# Altium schematic sheet P10_HDMI Framer.SchDoc
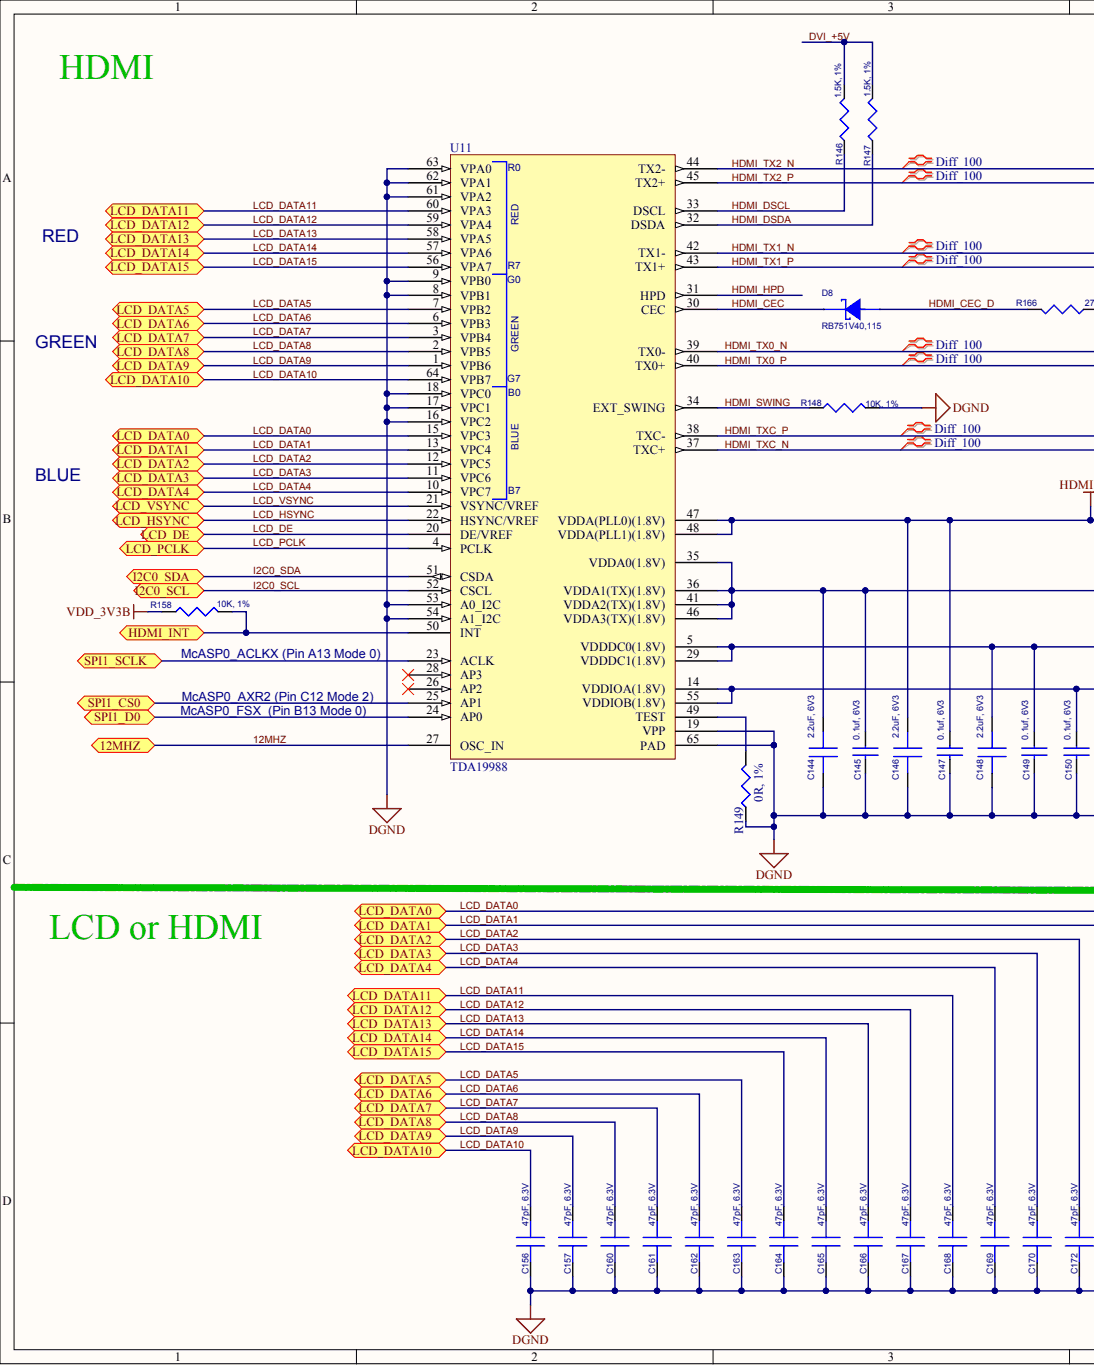
[diagram p0: P10_HDMI Framer.SchDoc, left]
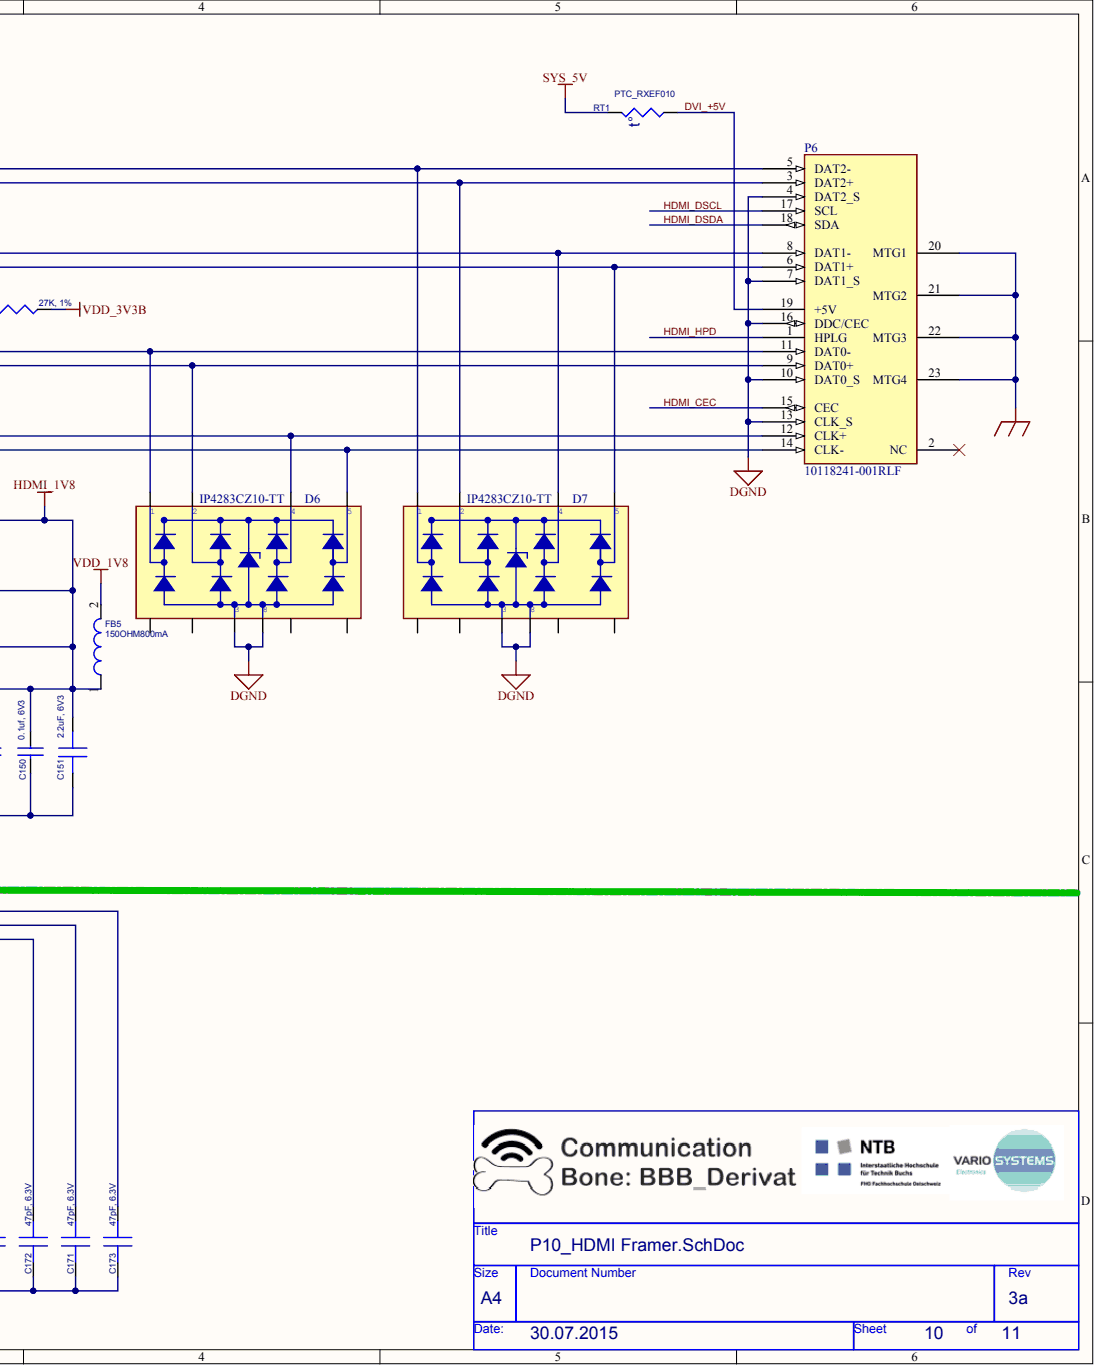
[diagram p1: P10_HDMI Framer.SchDoc, right]

## Parts
C144  2.2uF, 6V3  [402]
C145  0.1uf, 6V3  [402]
C146  2.2uF, 6V3  [402]
C147  0.1uf, 6V3  [402]
C148  2.2uF, 6V3  [402]
C149  0.1uf, 6V3  [402]
C150  0.1uf, 6V3  [402]
C151  2.2uF, 6V3  [402]
C156  47pF, 6.3V  [402]
C157  47pF, 6.3V  [402]
C160  47pF, 6.3V  [402]
C161  47pF, 6.3V  [402]
C162  47pF, 6.3V  [402]
C163  47pF, 6.3V  [402]
C164  47pF, 6.3V  [402]
C165  47pF, 6.3V  [402]
C166  47pF, 6.3V  [402]
C167  47pF, 6.3V  [402]
C168  47pF, 6.3V  [402]
C169  47pF, 6.3V  [402]
C170  47pF, 6.3V  [402]
C171  47pF, 6.3V  [402]
C172  47pF, 6.3V  [402]
C173  47pF, 6.3V  [402]
D6  IP4283CZ10-TT  [TSSOP10]
D7  IP4283CZ10-TT  [TSSOP10]
D8  RB751V40,115  [SOD323]
FB5  150OHM800mA  [SM-7351-IND-0805]
P6  10118241-001RLF  [CONN19_HDMI]
R146  1.5K, 1%  [402]
R147  1.5K, 1%  [402]
R148  10K, 1%  [402]
R149  0R, 1%  [402]
R158  10K, 1%  [402]
R166  27K, 1%  [402]
RT1  PTC_RXEF010  [POLYSW200-5638-310]
U11  TDA19988  [QFN64S9X9P5]

## Ports
12MHZ, HDMI_INT, I2C0_SCL, I2C0_SDA, LCD_DATA0, LCD_DATA1, LCD_DATA2, LCD_DATA3, LCD_DATA4, LCD_DATA5, LCD_DATA6, LCD_DATA7, LCD_DATA8, LCD_DATA9, LCD_DATA10, LCD_DATA11, LCD_DATA12, LCD_DATA13, LCD_DATA14, LCD_DATA15, LCD_DE, LCD_HSYNC, LCD_PCLK, LCD_VSYNC, SPI1_CS0, SPI1_D0, SPI1_SCLK

## Nets
DGND: C144.2 C145.2 C146.2 C147.2 C148.2 C149.2 C150.2 C151.2 C156.2 C157.2 C160.2 C161.2 C162.2 C163.2 C164.2 C165.2 C166.2 C167.2 C168.2 C169.2 C170.2 C171.2 C172.2 C173.2 D6.3 D6.8 D7.3 D7.8 P6.4(DAT2_S) P6.7(DAT1_S) P6.10(DAT0_S) P6.13(CLK_S) P6.16(DDC/CEC) R148.2 R149.2 U11.8(VPB1) U11.9(VPB0) U11.16(VPC2) U11.17(VPC1) U11.18(VPC0) U11.19(VPP) U11.53(A0_I2C) U11.54(A1_I2C) U11.61(VPA2) U11.62(VPA1) U11.63(VPA0) U11.65(PAD)
GND_EARTH: P6.20(MTG1) P6.21(MTG2) P6.22(MTG3) P6.23(MTG4)
HDMI_1V8: C144.1 C145.1 C146.1 C147.1 C148.1 C149.1 C150.1 C151.1 FB5.1 U11.5(VDDDC0(1.8V)) U11.14(VDDIOA(1.8V)) U11.29(VDDDC1(1.8V)) U11.35(VDDA0(1.8V)) U11.36(VDDA1(TX)(1.8V)) U11.41(VDDA2(TX)(1.8V)) U11.46(VDDA3(TX)(1.8V)) U11.47(VDDA(PLL0)(1.8V)) U11.48(VDDA(PLL1)(1.8V)) U11.55(VDDIOB(1.8V))
SYS_5V: RT1.1
VDD_1V8: FB5.2
VDD_3V3B: R158.1 R166.2
12MHZ: U11.27(OSC_IN) ; port 12MHZ
DVI_+5V: P6.19(+5V) R146.1 R147.1 RT1.2
HDMI_CEC: D8.1 P6.15(CEC) U11.30(CEC)
HDMI_CEC_D: D8.2 R166.1
HDMI_DSCL: P6.17(SCL) R146.2 U11.33(DSCL)
HDMI_DSDA: P6.18(SDA) R147.2 U11.32(DSDA)
HDMI_HPD: P6.1(HPLG) U11.31(HPD)
HDMI_INT: R158.2 U11.50(INT) ; port HDMI_INT
HDMI_SWING: R148.1 U11.34(EXT_SWING)
HDMI_TX0_N: D6.1 P6.11(DAT0-) U11.39(TX0-)
HDMI_TX0_P: D6.2 P6.9(DAT0+) U11.40(TX0+)
HDMI_TX1_N: D7.4 P6.8(DAT1-) U11.42(TX1-)
HDMI_TX1_P: D7.5 P6.6(DAT1+) U11.43(TX1+)
HDMI_TX2_N: D7.1 P6.5(DAT2-) U11.44(TX2-)
HDMI_TX2_P: D7.2 P6.3(DAT2+) U11.45(TX2+)
HDMI_TXC_N: D6.5 P6.14(CLK-) U11.37(TXC+)
HDMI_TXC_P: D6.4 P6.12(CLK+) U11.38(TXC-)
I2C0_SCL: U11.52(CSCL) ; port I2C0_SCL
I2C0_SDA: U11.51(CSDA) ; port I2C0_SDA
LCD_DATA0: C173.1 U11.15(VPC3) ; port LCD_DATA0
LCD_DATA1: C171.1 U11.13(VPC4) ; port LCD_DATA1
LCD_DATA2: C172.1 U11.12(VPC5) ; port LCD_DATA2
LCD_DATA3: C170.1 U11.11(VPC6) ; port LCD_DATA3
LCD_DATA4: C169.1 U11.10(VPC7) ; port LCD_DATA4
LCD_DATA5: C163.1 U11.7(VPB2) ; port LCD_DATA5
LCD_DATA6: C162.1 U11.6(VPB3) ; port LCD_DATA6
LCD_DATA7: C161.1 U11.3(VPB4) ; port LCD_DATA7
LCD_DATA8: C160.1 U11.2(VPB5) ; port LCD_DATA8
LCD_DATA9: C157.1 U11.1(VPB6) ; port LCD_DATA9
LCD_DATA10: C156.1 U11.64(VPB7) ; port LCD_DATA10
LCD_DATA11: C168.1 U11.60(VPA3) ; port LCD_DATA11
LCD_DATA12: C167.1 U11.59(VPA4) ; port LCD_DATA12
LCD_DATA13: C166.1 U11.58(VPA5) ; port LCD_DATA13
LCD_DATA14: C165.1 U11.57(VPA6) ; port LCD_DATA14
LCD_DATA15: C164.1 U11.56(VPA7) ; port LCD_DATA15
LCD_DE: U11.20(DE/VREF) ; port LCD_DE
LCD_HSYNC: U11.22(HSYNC/VREF) ; port LCD_HSYNC
LCD_PCLK: U11.4(PCLK) ; port LCD_PCLK
LCD_VSYNC: U11.21(VSYNC/VREF) ; port LCD_VSYNC
SPI1_CS0: U11.25(AP1) ; port SPI1_CS0
SPI1_D0: U11.24(AP0) ; port SPI1_D0
SPI1_SCLK: U11.23(ACLK) ; port SPI1_SCLK
NetR149_1: R149.1 U11.49(TEST)

Not connected: D6 6 7 9 10; D7 6 7 9 10; P6 2(NC); U11 26(AP2) 28(AP3)

## Library data of the parts
C144 C146 C148 C151 = CAP SMD: CAP CER 2.2UF 6.3V 10% X5R 0402; Manufacturer: TDK Corporation; Manufacturer Part Number: C1005X5R0J225K050BC; Capacitance: 2.2µF; Applications: General Purpose; Category: Capacitors; Component Kind: Standard; ComponentLink1Description: http://product.tdk.com/capacitor/mlcc/en/documents/mlcc_commercial_general_en.pdf; ComponentLink1URL: http://product.tdk.com/capacitor/mlcc/en/documents/mlcc_commercial_general_en.pdf; Features: -; Height - Seated (Max): -; Lead Spacing: -; Lead Style: -; Mounting Type: Surface Mount, MLCC; Operating Temperature: -55°C ~ 85°C; Package / Case: 0402 (1005 Metric); Packaging: Digi-Reel®; Pricing 1: 1=0.24, 10=0.2, 100=0.1, 250=0.075, 500=0.06626, 1000=0.05875, 5000=0.04875 (USD); Ratings: -; RoHS: RoHS Compliant; Size / Dimension: 0.039" L x 0.020" W (1.00mm x 0.50mm); Stock 1: 186346; Supplier 1: Digi-Key; Supplier Part Number 1: 445-6847-6-ND; Temperature Coefficient: X5R; Thickness (Max): 0.022" (0.55mm); Tolerance: ±10%; Voltage - Rated: 6.3V; Library Name: BBB_Derivat.SchLib
C145 C147 C149 C150 = CAP_0.1uF_X7R_16V_10% 0402: CAP CER 0.1UF 6.3V 10% X5R 0402; Manufacturer: TDK Corporation; Manufacturer Part Number: C1005X5R0J104K050BA; VS Part Number: 225065; Capacitance: 0.1µF; Applications: General Purpose; Category: Capacitors; Component Kind: Standard; ComponentLink1Description: http://www.kemet.com/datasheets&C0402C104K4RACTU; ComponentLink1URL: http://www.kemet.com/datasheets&C0402C104K4RACTU; Features: -; Height - Seated (Max): -; Lead Spacing: -; Lead Style: -; Mounting Type: Surface Mount, MLCC; Operating Temperature: -55°C ~ 85°C; Package / Case: 0402 (1005 Metric); Packaging: Digi-Reel®; Pricing 1: 1=0.1, 10=0.026, 100=0.0121, 250=0.00992, 500=0.00848, 1000=0.0066, 5000=0.0055 (USD); Ratings: -; RoHS: RoHS Compliant; Size / Dimension: 0.039" L x 0.020" W (1.00mm x 0.50mm); Stock 1: 127604; Supplier 1: Digi-Key; Supplier Part Number 1: 445-1266-6-ND; Temperature Coefficient: X5R; Thickness (Max): 0.022" (0.55mm); Tolerance: ±10%; Voltage - Rated: 6.3V; Library Name: BBB_Derivat.SchLib
C156 C157 C160-C173 = CAP_47pF_CER_50V_5%_0402: CAP CER 47PF 50V 5% NP0 0402; Manufacturer: TDK Corporation; Manufacturer Part Number: C1005C0G1H470J050BA; VS Part Number: 248027; Temperature Coefficient: C0G, NP0; Applications: General Purpose; Capacitance: 47pF; Category: Capacitors; Component Kind: Standard; ComponentLink1Description: http://product.tdk.com/capacitor/mlcc/en/documents/mlcc_commercial_general_en.pdf; ComponentLink1URL: http://product.tdk.com/capacitor/mlcc/en/documents/mlcc_commercial_general_en.pdf; Features: -; Height - Seated (Max): -; Lead Spacing: -; Lead Style: -; Mounting Type: Surface Mount, MLCC; Operating Temperature: -55°C ~ 125°C; Package / Case: 0402 (1005 Metric); Packaging: Digi-Reel®; Pricing 1: 1=0.1, 10=0.024, 100=0.011, 250=0.009, 500=0.0077, 1000=0.006, 5000=0.005 (USD); Ratings: -; RoHS: RoHS Compliant; Size / Dimension: 0.039" L x 0.020" W (1.00mm x 0.50mm); Stock 1: 0; Supplier 1: Digi-Key; Supplier Part Number 1: 445-1243-6-ND; Thickness (Max): 0.022" (0.55mm); Tolerance: ±5%; Voltage - Rated: 50V; Library Name: BBB_Derivat.SchLib
D6 D7 = 32CTQ030/TO_1: DIODE ESD PROT HDMI 10TSSOP; Manufacturer: NXP Semiconductors; Manufacturer Part Number: IP4283CZ10-TT,118; Polarization: 4 Channel Array - Unidirectional; Voltage - Breakdown: 6V; Voltage - Reverse Standoff (Typ): 3V; Category: Circuit Protection; Component Kind: Standard; ComponentLink1Description: http://media.digikey.com/pdf/Data%20Sheets/NXP%20PDFs/IP4283CZ10.pdf; ComponentLink1URL: http://media.digikey.com/pdf/Data%20Sheets/NXP%20PDFs/IP4283CZ10.pdf; Mounting Type: Surface Mount; Package / Case: 10-TFSOP, 10-MSOP (0.118", 3.00mm Width); Packaging: Digi-Reel®; Power (Watts): -; Pricing 1: 1=0.85, 10=0.743, 25=0.6568, 100=0.5724, 250=0.49828, 500=0.42416, 1000=0.33974 (USD); RoHS: RoHS Compliant; Stock 1: 1067; Supplier 1: Digi-Key; Supplier Device Package: 10-TSSOP; Supplier Part Number 1: 568-5875-6-ND; Library Name: BBB_Derivat.SchLib
D8 = Zener: DIODE SCHOTTKY 40V 120MA SOD323; Manufacturer: NXP Semiconductors; Capacitance @ Vr, F: 2pF @ 1V, 1MHz; Current - Average Rectified (Io): 120mA (DC); Current - Reverse Leakage @ Vr: 500nA @ 30V; Speed: Small Signal =< 200mA (Io), Any Speed; Voltage - Forward (Vf) (Max) @ If: 370mV @ 1mA; Category: Discrete Semiconductor Products; Component Kind: Standard; ComponentLink1Description: http://www.nxp.com/documents/data_sheet/RB751_SER.pdf; ComponentLink1URL: http://www.nxp.com/documents/data_sheet/RB751_SER.pdf; Diode Type: Schottky; Manufacturer Part Number: RB751V40,115; Mounting Type: Surface Mount; Package / Case: SC-76, SOD-323; Packaging: Digi-Reel®; Pricing 1: 1=0.29, 10=0.26, 25=0.1872, 100=0.1456, 250=0.09152, 500=0.07798, 1000=0.05313 (USD); Reverse Recovery Time (trr): -; RoHS: RoHS Compliant; Stock 1: 5326; Supplier 1: Digi-Key; Supplier Device Package: SOD-323; Supplier Part Number 1: 568-8119-6-ND; Voltage - DC Reverse (Vr) (Max): 40V; Library Name: BBB_Derivat.SchLib
FB5 = FER_180_200_0: FERRITE 800MA 150 OHM 0805 SMD; Manufacturer: Laird-Signal Integrity Products; Manufacturer Part Number: LI0805H151R-10; Mfr: Steward; PartName: FerriteBead150ohm800mA; TIIntPartnumber: 1L0010; DC Resistance (DCR): 150 mOhm Max; Filter Type: Differential Mode - Single; Impedance @ Frequency: 150 Ohm @ 100MHz; Vendor: Digikey; Category: Filters; Component Kind: Standard; ComponentLink1Description: http://www.lairdtech.com/WorkArea/linkit.aspx?LinkIdentifier=id&ItemID=4912; ComponentLink1URL: http://www.lairdtech.com/WorkArea/linkit.aspx?LinkIdentifier=id&ItemID=4912; Current Rating: 800mA; Height (Max): 0.043" (1.10mm); MfrNum: LI0805H151R-10; Mounting Type: Surface Mount; Package / Case: 0805 (2012 Metric); Packaging: Digi-Reel®; Pricing 1: 1=0.1, 10=0.07, 25=0.064, 50=0.0574, 100=0.0421, 250=0.03064, 500=0.02808, 1000=0.02424 (USD); RoHS: RoHS Compliant; Size / Dimension: 0.079" L x 0.049" W (2.00mm x 1.25mm); Stock 1: 3694; Supplier 1: Digi-Key; Supplier Part Number 1: 240-2384-6-ND; Library Name: BBB_Derivat.SchLib
P6 = Micro HDMI: CONN HDMI RECPT 19POS SMT R/A; Manufacturer: FCI; Gender: Receptacle; Number of Contacts: 19; Category: Connectors, Interconnects; Component Kind: Standard; ComponentLink1Description: http://portal.fciconnect.com/Comergent//fci/drawing/10118241.pdf; ComponentLink1URL: http://portal.fciconnect.com/Comergent//fci/drawing/10118241.pdf; Connector Type: HDMI; Features: Shielded, Solder Retention; Ingress Protection: -; Manufacturer Part Number: 10118241-001RLF; Mounting Type: Surface Mount, Right Angle, Horizontal; Packaging: Digi-Reel®; Pricing 1: 1=1.34, 10=1.174, 25=1.1052, 50=1.059, 100=1.013, 250=0.92092 (USD); RoHS: RoHS Compliant; Specifications: -; Stock 1: 6779; Supplier 1: Digi-Key; Supplier Part Number 1: 609-4615-6-ND; Library Name: BBB_Derivat.SchLib
R146 R147 = RES_1.5K_1/16W_5%_0402: RES 1.50K OHM 1/16W 1% 0402 SMD; Manufacturer: Yageo; Manufacturer Part Number: RC0402FR-071K5L; VS Part Number: 245875; Power (Watts): 0.063W, 1/16W; Temperature Coefficient: ±100ppm/°C; Category: Resistors; Component Kind: Standard; ComponentLink1Description: http://www.yageo.com/documents/recent/PYu-RC0402_51_RoHS_L_6.pdf; ComponentLink1URL: http://www.yageo.com/documents/recent/PYu-RC0402_51_RoHS_L_6.pdf; Composition: Thick Film; Features: -; Height: 0.016" (0.40mm); Number of Terminations: 2; Package / Case: 0402 (1005 Metric); Packaging: Digi-Reel®; Pricing 1: 1=0.1, 10=0.014, 25=0.0104, 100=0.0063, 250=0.0054, 500=0.00382, 1000=0.0027, 2500=0.00234, 5000=0.00203 (USD); Resistance (Ohms): 1.5k; RoHS: RoHS Compliant; Size / Dimension: 0.039" L x 0.020" W (1.00mm x 0.50mm); Stock 1: 465266; Supplier 1: Digi-Key; Supplier Device Package: 0402; Supplier Part Number 1: 311-1.50KLRDKR-ND; Tolerance: ±1%; Library Name: BBB_Derivat.SchLib
R148 R158 = RES_10K_1/16W_1%_0402: RES 10K OHM 1/16W 5% 0402 SMD; Manufacturer: Rohm Semiconductor; Manufacturer Part Number: MCR01MZPJ103; VS Part Number: 226218; Power (Watts): 0.063W, 1/16W; Temperature Coefficient: ±200ppm/°C; Category: Resistors; Component Kind: Standard; ComponentLink1Description: http://rohmfs.rohm.com/en/products/databook/datasheet/passive/resistor/chip_resistor/mcr_a.pdf; ComponentLink1URL: http://rohmfs.rohm.com/en/products/databook/datasheet/passive/resistor/chip_resistor/mcr_a.pdf; Composition: Thick Film; Features: Automotive AEC-Q200 Qualified; Height: 0.016" (0.40mm); Number of Terminations: 2; Package / Case: 0402 (1005 Metric); Packaging: Digi-Reel®; Resistance (Ohms): 10k; RoHS: RoHS Compliant; Size / Dimension: 0.039" L x 0.020" W (1.00mm x 0.50mm); Supplier 1: Digi-Key; Supplier Device Package: 0402 (1005 Metric); Supplier Part Number 1: RHM10KCEDKR-ND; Tolerance: ±5%; Library Name: BBB_Derivat.SchLib
R149 = RES_0_1/16W_1%_0402: RES 0.0 OHM 1/16W 0402 SMD; Manufacturer: Yageo; Manufacturer Part Number: RC0402JR-070RL; VS Part Number: 226229; Power (Watts): 0.063W, 1/16W; Resistance (Ohms): 0.0; Tolerance: Jumper; Category: Resistors; Component Kind: Standard; ComponentLink1Description: http://www.yageo.com/documents/recent/PYu-RC0402_51_RoHS_L_6.pdf; ComponentLink1URL: http://www.yageo.com/documents/recent/PYu-RC0402_51_RoHS_L_6.pdf; Composition: Thick Film; Features: -; Height: 0.016" (0.40mm); Number of Terminations: 2; Package / Case: 0402 (1005 Metric); Packaging: Digi-Reel®; Pricing 1: 1=0.1, 10=0.012, 25=0.0092, 100=0.0055, 250=0.00476, 500=0.00336, 1000=0.00237, 2500=0.00206, 5000=0.00178 (USD); RoHS: RoHS Compliant; Size / Dimension: 0.039" L x 0.020" W (1.00mm x 0.50mm); Stock 1: 4904429; Supplier 1: Digi-Key; Supplier Device Package: 0402; Supplier Part Number 1: 311-0.0JRDKR-ND; Temperature Coefficient: -; Library Name: BBB_Derivat.SchLib
R166 = RES_27.0K_1/16W_1%_0402: RES 27.0K OHM 1/16W 1% 0402 SMD; Manufacturer: Yageo; Manufacturer Part Number: RC0402FR-0727KL; VS Part Number: 245840; Power (Watts): 0.063W, 1/16W; Temperature Coefficient: ±100ppm/°C; Category: Resistors; Component Kind: Standard; ComponentLink1Description: http://www.yageo.com/documents/recent/PYu-RC0402_51_RoHS_L_6.pdf; ComponentLink1URL: http://www.yageo.com/documents/recent/PYu-RC0402_51_RoHS_L_6.pdf; Composition: Thick Film; Features: -; Height: 0.016" (0.40mm); Number of Terminations: 2; Package / Case: 0402 (1005 Metric); Packaging: Digi-Reel®; Pricing 1: 1=0.1, 10=0.014, 25=0.0104, 100=0.0063, 250=0.0054, 500=0.00382, 1000=0.0027, 2500=0.00234, 5000=0.00203 (USD); Resistance (Ohms): 27k; RoHS: RoHS Compliant; Size / Dimension: 0.039" L x 0.020" W (1.00mm x 0.50mm); Stock 1: 115508; Supplier 1: Digi-Key; Supplier Device Package: 0402; Supplier Part Number 1: 311-27.0KLRDKR-ND; Tolerance: ±1%; Library Name: BBB_Derivat.SchLib
RT1 = PTC: POLYSWITCH PTC RESET 0.1A; Manufacturer: TE Connectivity; Current - Hold (Ih) (Max): 100mA; Current - Max: 40A; Current - Trip (It): 200mA; R Min/Max: 2.500 ~ 4.500 Ohm; Time to Trip: 4s; Voltage - Max: 60V; Category: Circuit Protection; Component Kind: Standard; ComponentLink1Description: http://documents.tycoelectronics.com/commerce/DocumentDelivery/DDEController?Action=showdoc&DocId=Specification+Or+Standard%7FSCD25213%7FE%7Fpdf%7FEnglish%7FENG_SS_SCD25213_E.pdf; ComponentLink1URL: http://documents.tycoelectronics.com/commerce/DocumentDelivery/DDEController?Action=showdoc&DocId=Specification+Or+Standard%7FSCD25213%7FE%7Fpdf%7FEnglish%7FENG_SS_SCD25213_E.pdf; Manufacturer Part Number: RXEF010; Package / Case: Radial, Disc; Packaging: Bulk; Pricing 1: 1=0.32, 10=0.289, 100=0.2625, 500=0.20476, 1000=0.1764, 5000=0.1617, 10000=0.1365, 50000=0.13125, 100000=0.126 (USD); RoHS: RoHS Compliant; Stock 1: 22325; Supplier 1: Digi-Key; Supplier Part Number 1: RXEF010-ND; Library Name: BBB_Derivat.SchLib
U11 = TDA19988: IC HDMI TRANSMITTER 64-HVQFN; Manufacturer: NXP Semiconductors; Manufacturer Part Number: TDA19988AHN/C1,551; Applications: Set-Top Boxes; Category: Integrated Circuits (ICs); Component Kind: Standard; Mounting Type: Surface Mount; Package / Case: 64-VFQFN Exposed Pad; Packaging: Tray; RoHS: RoHS Compliant; Supplier 1: Mouser; Supplier Device Package: 64-HVQFN (9x9); Supplier Part Number 1: 771-TDA19988BHNC1557; Type: HDMI Transmitter; Library Name: BBB_Derivat.SchLib
